# Altium schematic sheet Sheet3.SchDoc(Sheet3)
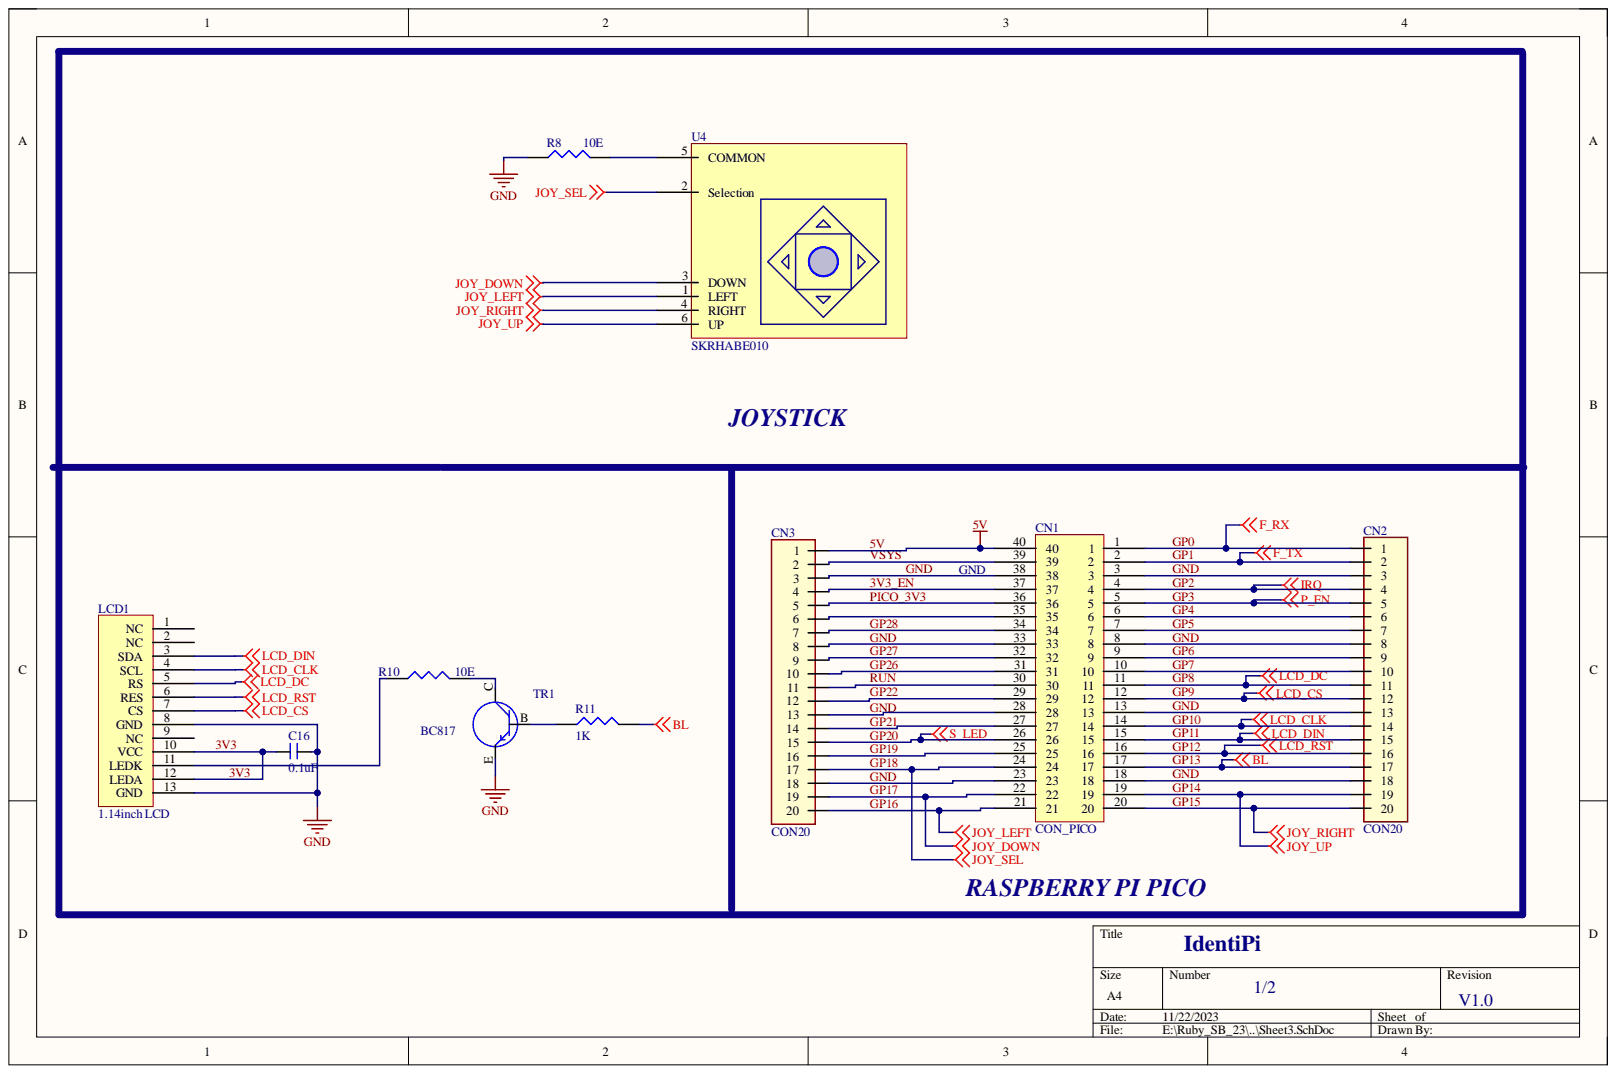
[diagram p0: Sheet3.SchDoc]

## Parts
C16  0.1uF  [C_0603]
CN1  CON_PICO  [HAT_CONN-40P/2.54MM_SMD]
CN2  CON20  [20P TH]
CN3  CON20  [20P TH]
LCD1  1.14inch LCD  [1.14 inch]
R8  10E  [R_0603]
R10  10E  [R_0603]
R11  1K  [R_0603]
TR1  BC817  [SOT23]
U4  SKRHABE010  [JOY_STICK]

## Nets
3V3: C16.2 LCD1.10(VCC) LCD1.12(LEDA)
3V3_EN: CN1.37 CN3.4
5V: CN1.40 CN3.1
GND: C16.1 CN1.3 CN1.8 CN1.13 CN1.18 CN1.23 CN1.28 CN1.33 CN1.38 CN2.3 CN2.8 CN2.13 CN2.18 CN3.3 CN3.8 CN3.13 CN3.18 LCD1.8(GND) LCD1.13(GND) R8.1 TR1.E
GP0: CN1.1 CN2.1
GP1: CN1.2 CN2.2
GP2: CN1.4 CN2.4
GP3: CN1.5 CN2.5
GP4: CN1.6 CN2.6
GP5: CN1.7 CN2.7
GP6: CN1.9 CN2.9
GP7: CN1.10 CN2.10
GP8: CN1.11 CN2.11 LCD1.5(RS)
GP9: CN1.12 CN2.12 LCD1.7(CS)
GP10: CN1.14 CN2.14 LCD1.4(SCL)
GP11: CN1.15 CN2.15 LCD1.3(SDA)
GP12: CN1.16 CN2.16 LCD1.6(RES)
GP13: CN1.17 CN2.17 R11.1
GP14: CN1.19 CN2.19 U4.6(UP)
GP15: CN1.20 CN2.20 U4.4(RIGHT)
GP16: CN1.21 CN3.20 U4.1(LEFT)
GP17: CN1.22 CN3.19 U4.3(DOWN)
GP18: CN1.24 CN3.17 U4.2(Selection)
GP19: CN1.25 CN3.16
GP20: CN1.26 CN3.15
GP21: CN1.27 CN3.14
GP22: CN1.29 CN3.12
GP26: CN1.31 CN3.10
GP27: CN1.32 CN3.9
GP28: CN1.34 CN3.7
PICO_3V3: CN1.36 CN3.5
RUN: CN1.30 CN3.11
VSYS: CN1.39 CN3.2
NetCN1_35: CN1.35 CN3.6
NetLCD1_11: LCD1.11(LEDK) R10.1
NetR8_2: R8.2 U4.5(COMMON)
NetR10_2: R10.2 TR1.C
NetR11_2: R11.2 TR1.B

Not connected: LCD1 1(NC) 2(NC) 9(NC)

## Library data of the parts
C16 = CAP_NONPOLAR: Component Kind: Standard; Library Name: dxp_pasl_lib.IntLib
CN1 = CON40_PICO TOP MALE BERG: Component Kind: Standard; Library Name: dxp_pasl_lib.IntLib
LCD1 = LCD 1.14INCH: 1.14inch LCD Display 65K RGB Colors, 240×135 Pixels, SPI Interface; Component Kind: Standard; Library Name: dxp_pasl_lib.IntLib
R8 R10 R11 = RES: Component Kind: Standard; Library Name: dxp_pasl_lib.IntLib
TR1 = TRANSISTER_NPN: Component Kind: Standard; Library Name: dxp_pasl_lib.IntLib
U4 = SKRHABE010: SMD 5-way Tactile Switches RoHS #C139794; Component Kind: Standard; Library Name: dxp_pasl_lib.IntLib
CN2 CN3 = CON20: Component Kind: Standard; Library Name: dxp_pasl_lib.SCHLIB
